# Altium schematic sheet PART_2.SchDoc
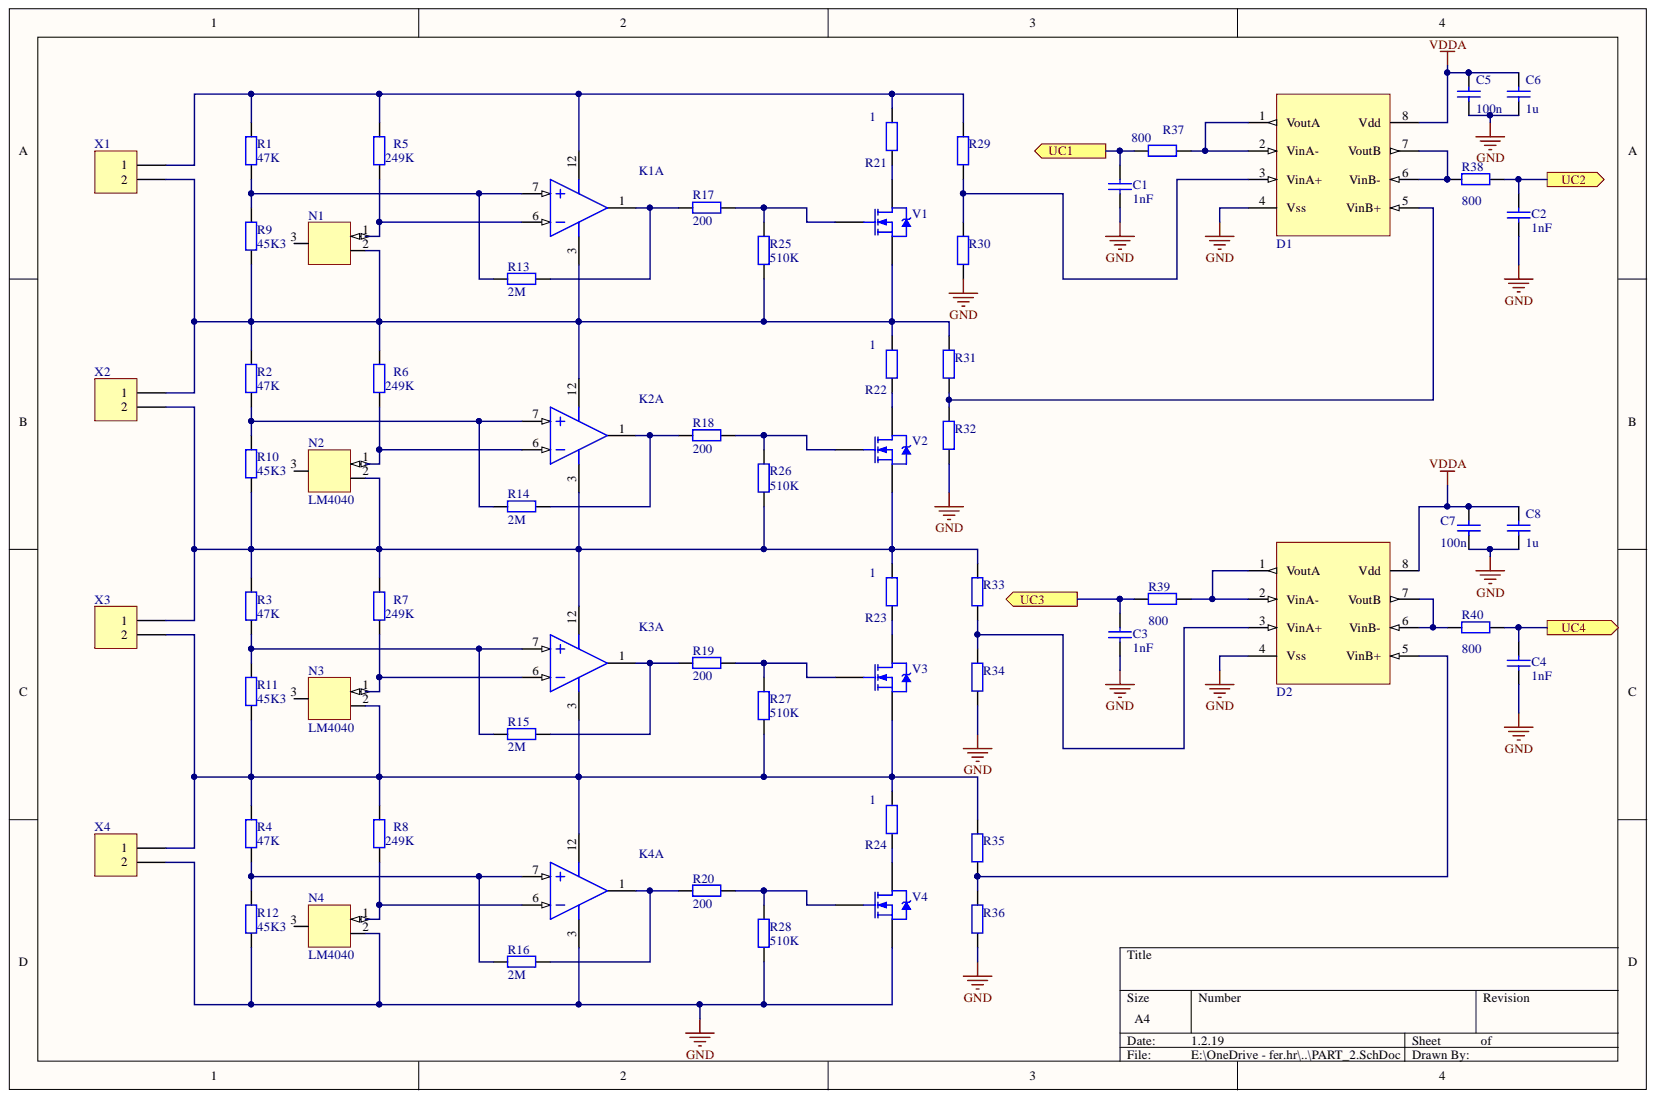
[diagram p0: PART_2.SchDoc]

## Parts
C1  value 1nF  [C0805]  (hidden comment: Cap)
C2  value 1nF  [C0805]  (hidden comment: Cap)
C3  value 1nF  [C0805]  (hidden comment: Cap)
C4  value 1nF  [C0805]  (hidden comment: Cap)
C5  100n  [C0805]
C6  1u  [C0805]
C7  100n  [C0805]
C8  1u  [C0805]
D1  (no value)  [MSOP za MCP6242]
D2  (no value)  [MSOP za MCP6242]
K1  TLC3704QJ  [TSSOP5-3]  (value not drawn)  (part A)
K2  TLC3704QJ  [TSSOP5-3]  (value not drawn)  (part A)
K3  TLC3704QJ  [TSSOP5-3]  (value not drawn)  (part A)
K4  TLC3704QJ  [TSSOP5-3]  (value not drawn)  (part A)
N1  (no value)  [SOT-23-ref_chip]
N2  LM4040  [SOT-23-ref_chip]
N3  LM4040  [SOT-23-ref_chip]
N4  LM4040  [SOT-23-ref_chip]
R1  47K  [C0805]
R2  47K  [C0805]
R3  47K  [C0805]
R4  47K  [C0805]
R5  249K  [C0805]
R6  249K  [C0805]
R7  249K  [C0805]
R8  249K  [C0805]
R9  45K3  [C0805]
R10  45K3  [C0805]
R11  45K3  [C0805]
R12  45K3  [C0805]
R13  2M  [C0805]
R14  2M  [C0805]
R15  2M  [C0805]
R16  2M  [C0805]
R17  200  [C0805]
R18  200  [C0805]
R19  200  [C0805]
R20  200  [C0805]
R21  1  [F za 7W otpornik]
R22  1  [F za 7W otpornik]
R23  1  [F za 7W otpornik]
R24  1  [F za 7W otpornik]
R25  510K  [C0805]
R26  510K  [C0805]
R27  510K  [C0805]
R28  510K  [C0805]
R29  (no value)  [C0805]
R30  (no value)  [C0805]
R31  (no value)  [C0805]
R32  (no value)  [C0805]
R33  (no value)  [C0805]
R34  (no value)  [C0805]
R35  (no value)  [C0805]
R36  (no value)  [C0805]
R37  value 800  [C0805]
R38  value 800  [C0805]
R39  value 800  [C0805]
R40  value 800  [C0805]
V1  NMOS-2  [SO-8L single_f]  (value not drawn)
V2  NMOS-2  [SO-8L single_f]  (value not drawn)
V3  NMOS-2  [SO-8L single_f]  (value not drawn)
V4  NMOS-2  [SO-8L single_f]  (value not drawn)
X1  Header 2  [F Header 1x2]  (value not drawn)
X2  Header 2  [F Header 1x2]  (value not drawn)
X3  Header 2  [F Header 1x2]  (value not drawn)
X4  Header 2  [F Header 1x2]  (value not drawn)

## Ports
UC1, UC2, UC3, UC4

## Nets
GND: C1.1 C2.1 C3.1 C4.1 C5.2 C6.2 C7.2 C8.2 D1.4(Vss) D2.4(Vss) K4.3 N4.2 R12.1 R28.1 R30.1 R32.1 R34.1 R36.1 V4.3 X4.2
VDDA: C5.1 C6.1 C7.1 C8.1 D1.8(Vdd) D2.8(Vdd)
UC1: C1.2 R37.2 ; port UC1
UC2: C2.2 R38.2 ; port UC2
UC3: C3.2 R39.1 ; port UC3
UC4: C4.2 R40.2 ; port UC4
NetD1_1: D1.1(VoutA) D1.2(VinA-) R37.1
NetD1_3: D1.3(VinA+) R29.1 R30.2
NetD1_5: D1.5(VinB+) R31.1 R32.2
NetD1_6: D1.6(VinB-) D1.7(VoutB) R38.1
NetD2_1: D2.1(VoutA) D2.2(VinA-) R39.2
NetD2_3: D2.3(VinA+) R33.1 R34.2
NetD2_5: D2.5(VinB+) R35.1 R36.2
NetD2_6: D2.6(VinB-) D2.7(VoutB) R40.1
NetK1_1: K1.1 R13.1 R17.2
NetK1_3: K1.3 K2.12 N1.2 R2.2 R6.2 R9.1 R22.2 R25.1 R31.2 V1.3 X1.2 X2.1
NetK1_6: K1.6 N1.1 R5.1
NetK1_7: K1.7 R1.1 R9.2 R13.2
NetK1_12: K1.12 R1.2 R5.2 R21.2 R29.2 X1.1
NetK2_1: K2.1 R14.1 R18.2
NetK2_3: K2.3 K3.12 N2.2 R3.2 R7.2 R10.1 R23.2 R26.1 R33.2 V2.3 X2.2 X3.1
NetK2_6: K2.6 N2.1 R6.1
NetK2_7: K2.7 R2.1 R10.2 R14.2
NetK3_1: K3.1 R15.1 R19.2
NetK3_3: K3.3 K4.12 N3.2 R4.2 R8.2 R11.1 R24.2 R27.1 R35.2 V3.3 X3.2 X4.1
NetK3_6: K3.6 N3.1 R7.1
NetK3_7: K3.7 R3.1 R11.2 R15.2
NetK4_1: K4.1 R16.1 R20.2
NetK4_6: K4.6 N4.1 R8.1
NetK4_7: K4.7 R4.1 R12.2 R16.2
NetR17_1: R17.1 R25.2 V1.1
NetR18_1: R18.1 R26.2 V2.1
NetR19_1: R19.1 R27.2 V3.1
NetR20_1: R20.1 R28.2 V4.1
NetR21_1: R21.1 V1.2
NetR22_1: R22.1 V2.2
NetR23_1: R23.1 V3.2
NetR24_1: R24.1 V4.2

Not connected: N1 3; N2 3; N3 3; N4 3

## Library data of the parts
C1-C4 C6 C8 = Cap: Capacitor; Component Kind: Standard; Library Name: Miscellaneous Devices.IntLib; Signal Integrity: Cap; Simulation: CAP
  C6 C8: Value: 1u
C5 C7 = Cap: Capacitor; Value: 100nF; Component Kind: Standard; Library Name: Miscellaneous Devices.IntLib; Signal Integrity: Cap; Simulation: CAP
D1 D2 = Amplifier: cijena (funte): 0.29; dobavljač: Farnell; kataloški broj: 1840875; opis komponente: Operational Amplifier; Component Kind: Standard; Library Name: komponente.SchLib
K1-K4 = LP2901D: Low Power Quad Differential Comparator; cijena (funte): 0.325; dobavljač: Farnell; kataloški broj: 2164804; opis komponente: Analogue Comparator; Component Kind: Standard; Library Name: TI Analog Comparator.IntLib; PCB3D: D014; Simulation: LP2901
N1-N4 = referentni chip: cijena (funte): 0.567; dobavljač: Farnell; kataloški broj: 2511278; opis komponente: chip za ref napon; Value: 1.25V; Component Kind: Standard; Library Name: komponente.SchLib
R1-R4 = Res2: Resistor; cijena (funte): 0.172; dobavljač: Farnell; kataloški broj: 1577628; opis komponente: resistor, 0.1%, 100mW; Component Kind: Standard; Library Name: Miscellaneous Devices.IntLib; Signal Integrity: Res; Simulation: RESISTOR; Value: 47K
R5-R8 = Res2: Resistor; cijena (funte): 0.0452; dobavljač: Farnell; kataloški broj: 2057658; opis komponente: resistor, 1%, 125mW; Component Kind: Standard; Library Name: Miscellaneous Devices.IntLib; Signal Integrity: Res; Simulation: RESISTOR; Value: 249K
R9-R12 = Res2: Resistor; cijena (funte): 0.0173; dobavljač: Farnell; kataloški broj: 2073774; opis komponente: resistor, 125 mW, 1%; Component Kind: Standard; Library Name: Miscellaneous Devices.IntLib; Signal Integrity: Res; Simulation: RESISTOR; Value: 45K3
R13-R16 = Res2: Resistor; cijena (funte): 0.0106; dobavljač: Farnell; kataloški broj: 2447628; opis komponente: resistor, 125mW; Component Kind: Standard; Library Name: Miscellaneous Devices.IntLib; Signal Integrity: Res; Simulation: RESISTOR; Value: 2M
R17-R20 = Res2: Resistor; cijena (funte): 0.084; dobavljač: Farnell; kataloški broj: 9334076; opis komponente: resistor, 100mW; Component Kind: Standard; Library Name: Miscellaneous Devices.IntLib; Signal Integrity: Res; Simulation: RESISTOR; Value: 200
R21-R24 = Res2: Resistor; cijena (funte): 0.426; dobavljač: Farnell; kataloški broj: 1292518; opis komponente: Through Hole Resistor 7W; Component Kind: Standard; Library Name: Miscellaneous Devices.IntLib; Signal Integrity: Res; Simulation: RESISTOR; Value: 1
R25-R28 = Res2: Resistor; cijena (funte): 0.0086; dobavljač: Farnell; kataloški broj: 2447678; opis komponente: rsistor, 125mW; Component Kind: Standard; Library Name: Miscellaneous Devices.IntLib; Signal Integrity: Res; Simulation: RESISTOR; Value: 510K
R29-R36 = Res2: Resistor; dobavljač: Farnell; Component Kind: Standard; Library Name: Miscellaneous Devices.IntLib; opis komponente: resistor; Signal Integrity: Res; Simulation: RESISTOR
R37 R38 R40 = Res2: Resistor; dobavljač: Farnell; kataloški broj: 2303540; Component Kind: Standard; Library Name: Miscellaneous Devices.IntLib; opis komponente: resistor; Signal Integrity: Res; Simulation: RESISTOR
R39 = Res2: Resistor; dobavljač: Farnell; kataloški broj: 2303540; Value: 800; Component Kind: Standard; Library Name: Miscellaneous Devices.IntLib; opis komponente: resistor; Signal Integrity: Res; Simulation: RESISTOR
V1-V4 = NMOS-2: N-Channel Power MOSFET; cijena (funte): 0.388; dobavljač: Farnell; kataloški broj: 2396085; opis komponente: N-MOSFET; Component Kind: Standard; Library Name: Miscellaneous Devices.IntLib; Simulation: IRF1010
X1-X4 = Header 2: Header, 2-Pin; cijena (funte): 0.239; dobavljač: Farnell; kataloški broj: 2396251; Component Kind: Standard; Library Name: Miscellaneous Connectors.IntLib; opis komponente: Header, 2-Pin; Signal Integrity: Connector
